# Altium schematic sheet FPGA_AlimPeriph.SchDoc
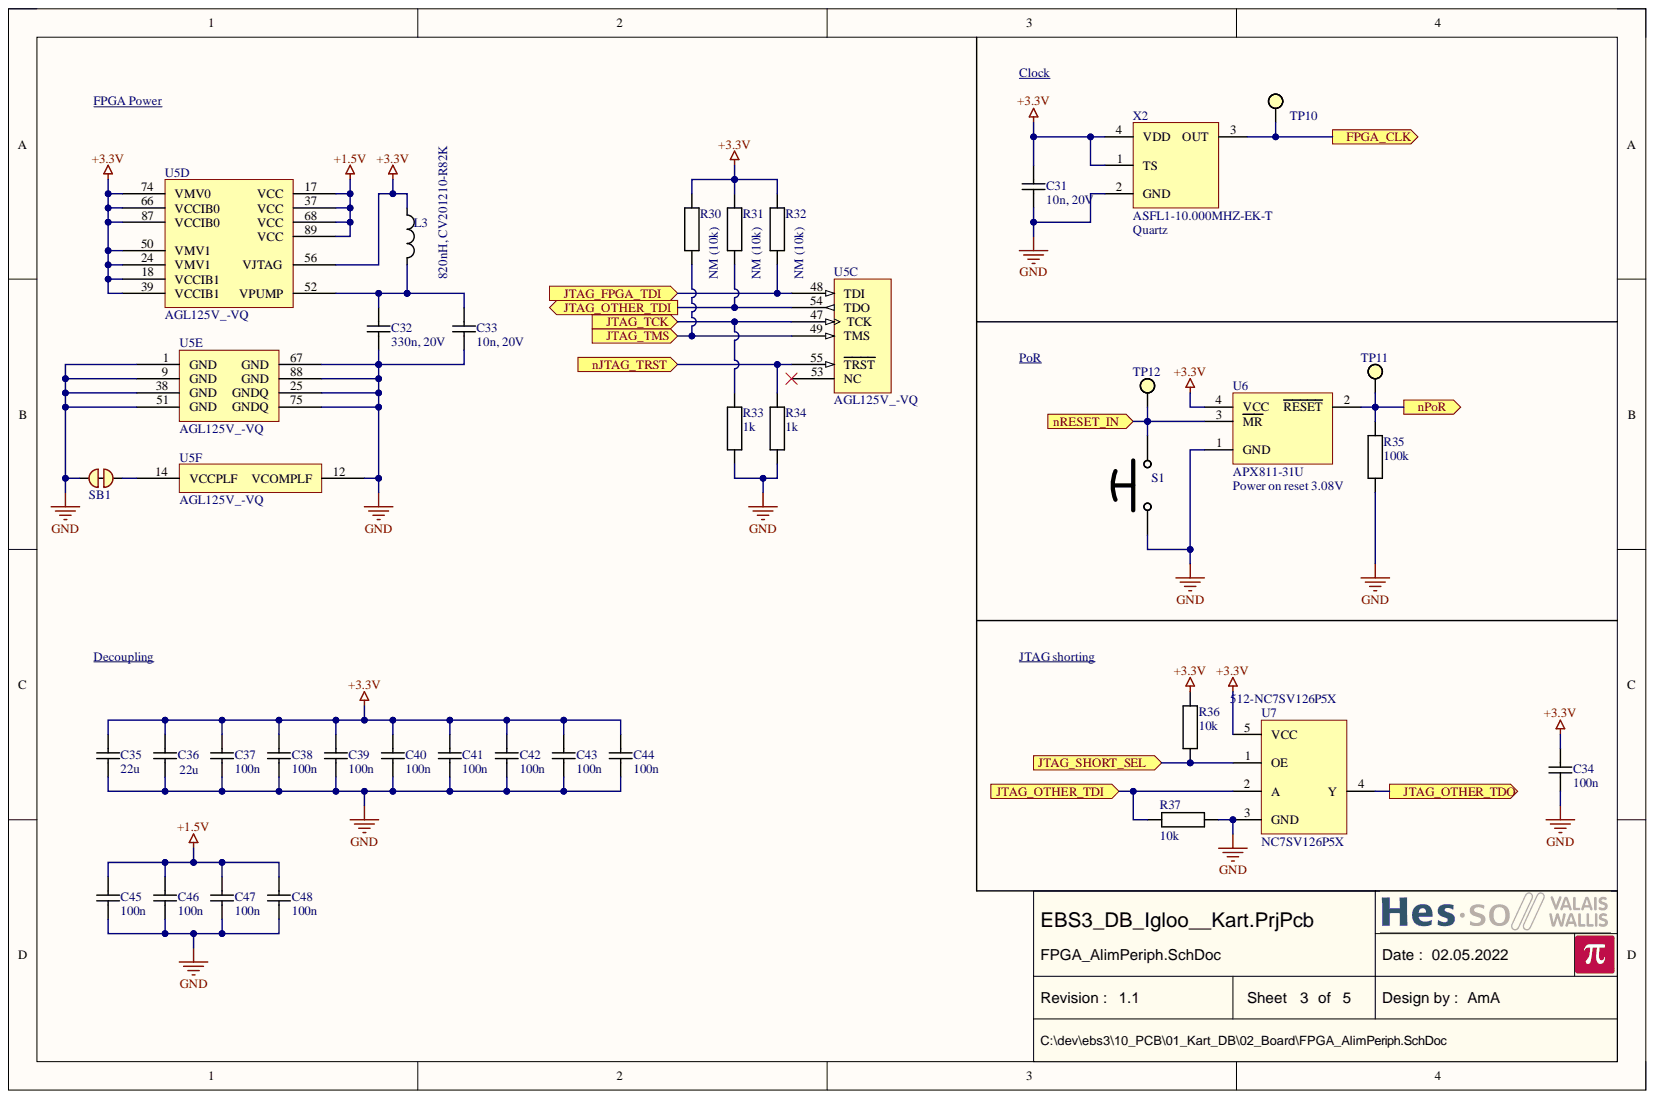
[diagram p0: FPGA_AlimPeriph.SchDoc]

## Parts
C31  10n, 20V  [CAPACITOR 1608 REFLOW]
C32  330n, 20V  [CAPACITOR 1608 REFLOW]
C33  10n, 20V  [CAPACITOR 1608 REFLOW]
C34  100n  [CAPACITOR 1608 REFLOW]
C35  22u  [CAPACITOR 2012 REFLOW]
C36  22u  [CAPACITOR 2012 REFLOW]
C37  100n  [CAPACITOR 1608 REFLOW]
C38  100n  [CAPACITOR 1608 REFLOW]
C39  100n  [CAPACITOR 1608 REFLOW]
C40  100n  [CAPACITOR 1608 REFLOW]
C41  100n  [CAPACITOR 1608 REFLOW]
C42  100n  [CAPACITOR 1608 REFLOW]
C43  100n  [CAPACITOR 1608 REFLOW]
C44  100n  [CAPACITOR 1608 REFLOW]
C45  100n  [CAPACITOR 1608 REFLOW]
C46  100n  [CAPACITOR 1608 REFLOW]
C47  100n  [CAPACITOR 1608 REFLOW]
C48  100n  [CAPACITOR 1608 REFLOW]
L3  820nH, CV201210-R82K  [INDUCTOR 2012 REFLOW]
R30  NM (10k)  [RESISTOR 1608 REFLOW]
R31  NM (10k)  [RESISTOR 1608 REFLOW]
R32  NM (10k)  [RESISTOR 1608 REFLOW]
R33  1k  [RESISTOR 1608 REFLOW]
R34  1k  [RESISTOR 1608 REFLOW]
R35  100k  [RESISTOR 1608 REFLOW]
R36  10k  [RESISTOR 1608 REFLOW]
R37  10k  [RESISTOR 1608 REFLOW]
S1  (no value)  [Push Button C2.5X3.0 OMRON B3U-1000P(M)-B]
SB1  (no value)  [Solder Bridge 1mm Connected]
TP10  ARWIN S2761-46R  [Test Point ARWIN S2761-46R]  (value not drawn)
TP11  ARWIN S2761-46R  [Test Point ARWIN S2761-46R]  (value not drawn)
TP12  ARWIN S2761-46R  [Test Point ARWIN S2761-46R]  (value not drawn)
U5  AGL125V_-VQ  [VQ100 P0.5 C14x14 H1.2]  (parts C,D,E,F)
U6  APX811-31U  [SOT143 P1.9 C1.4X3.0 H1.1]
U7  NC7SV126P5X  Supplier Part Number 1: 512-NC7SV126P5X  [SC70-5 P0.65 C1.4x2.2 H1.0]
X2  ASFL1-10.000MHZ-EK-T  [Oscillator C5.0x3.2 Abracon ASFL1]

## Ports
FPGA_CLK, JTAG_FPGA_TDI, JTAG_OTHER_TDI, JTAG_OTHER_TDO, JTAG_SHORT_SEL, JTAG_TCK, JTAG_TMS, nJTAG_TRST, nPoR, nRESET_IN

## Nets
+1.5V: C45.2 C46.2 C47.2 C48.2 U5.17(VCC) U5.37(VCC) U5.68(VCC) U5.89(VCC)
+3.3V: C31.2 C34.2 C35.2 C36.2 C37.2 C38.2 C39.2 C40.2 C41.2 C42.2 C43.2 C44.2 L3.2 R30.2 R31.2 R32.2 R36.1 U5.18(VCCIB1) U5.24(VMV1) U5.39(VCCIB1) U5.50(VMV1) U5.56(VJTAG) U5.66(VCCIB0) U5.74(VMV0) U5.87(VCCIB0) U6.4(VCC) U7.5(VCC) X2.1(TS) X2.4(VDD)
GND: C31.1 C32.1 C33.1 C34.1 C35.1 C36.1 C37.1 C38.1 C39.1 C40.1 C41.1 C42.1 C43.1 C44.1 C45.1 C46.1 C47.1 C48.1 R33.1 R34.1 R35.1 R37.2 S1.2 SB1.2 U5.1(GND) U5.9(GND) U5.12(VCOMPLF) U5.25(GNDQ) U5.38(GND) U5.51(GND) U5.67(GND) U5.75(GNDQ) U5.88(GND) U6.1(GND) U7.3(GND) X2.2(GND)
FPGA_CLK: TP10.1 X2.3(OUT) ; port FPGA_CLK
JTAG_FPGA_TDI: R32.1 U5.48(TDI) ; port JTAG_FPGA_TDI
JTAG_OTHER_TDI: R31.1 R37.1 U5.54(TDO) U7.2(A) ; port JTAG_OTHER_TDI
JTAG_OTHER_TDO: U7.4(Y) ; port JTAG_OTHER_TDO
JTAG_SHORT_SEL: R36.2 U7.1(OE) ; port JTAG_SHORT_SEL
JTAG_TCK: R33.2 U5.47(TCK) ; port JTAG_TCK
JTAG_TMS: R30.1 U5.49(TMS) ; port JTAG_TMS
nJTAG_TRST: R34.2 U5.55(TRST) ; port nJTAG_TRST
nPoR: R35.2 TP11.1 U6.2(RESET) ; port nPoR
nRESET_IN: S1.1 TP12.1 U6.3(MR) ; port nRESET_IN
NetC32_2: C32.2 C33.2 L3.1 U5.52(VPUMP)
NetSB1_1: SB1.1 U5.14(VCCPLF)

Not connected: U5 53(NC)

## Library data of the parts
C31-C48 = Capacitor: Component Kind: Standard; Description: Capacitor; Library Name: Capacitor.IntLib
  C31 C33: Value: 10n, 20V
  C32: Value: 330n, 20V
  C34 C37-C48: Value: 100n
  C35 C36: Value: 22u
L3 = Inductor: Component Kind: Standard; Description: Inductor; Library Name: Inductor.IntLib; Value: 820nH, CV201210-R82K
R30-R37 = Resistor: Component Kind: Standard; Description: Resistor; Library Name: Resistor.IntLib
  R30-R32: Value: NM (10k)
  R33 R34: Value: 1k
  R35: Value: 100k
  R36 R37: Value: 10k
S1 = Push Button 2Pins: Push Button, 2 pins; Component Kind: Standard; Library Name: Button.IntLib
SB1 = Solderbridge Connected: Component Kind: Net Tie; Description: Solderbridge Connected; Library Name: Jumper.IntLib
TP10-TP12 = Test Point: Component Kind: Standard; Description: Test Point; Library Name: Testpoint.IntLib; Value: ARWIN S2761-46R
U5 = AGL125V_-VQ: IGLOO Low Power Flash FPGAs; Component Kind: Standard; Library Name: Processor.IntLib; Value: AGL125V_-VQ
U6 = APX811-__U: 4-Pin Microprocessor Supervisor With Manual Reset; Component Kind: Standard; Library Name: IntegratedCircuit.IntLib; Value: APX811-31U
U7 = NC7SV126P5X: single 3−State buffer; Component Kind: Standard; Library Name: IntegratedCircuit.IntLib; Supplier 1: Mouser; Value: NC7SV126P5X
X2 = Oscillator C5.0x3.2 Abracon ASFL1: Component Kind: Standard; Description: Oscillator C5.0x3.2 Abracon ASFL1; Library Name: Oscillator.IntLib; Value: ASFL1-10.000MHZ-EK-T
